# Altium schematic sheet LS1.SchDoc(LS1)
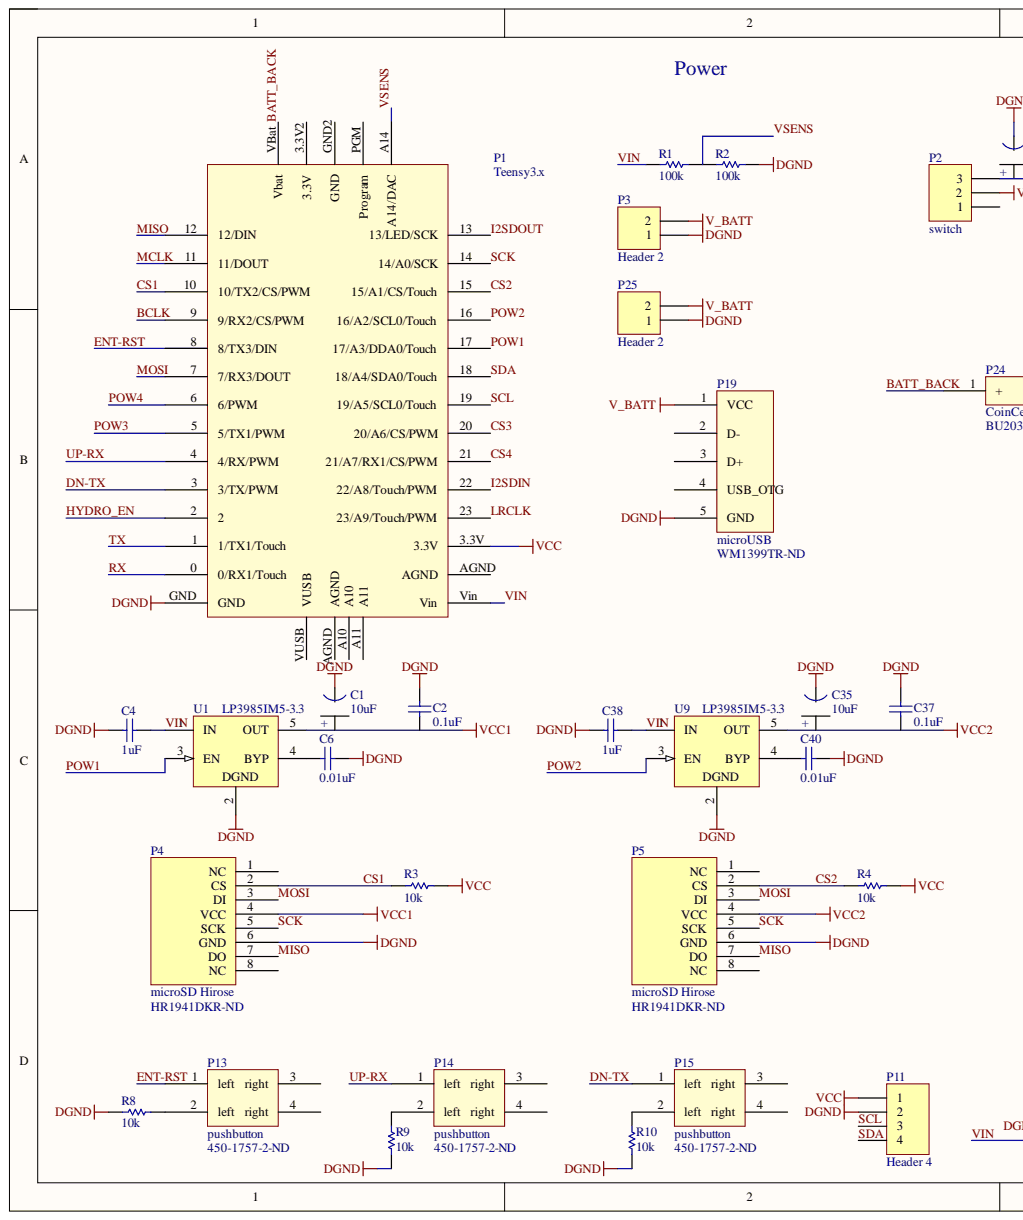
[diagram p0: LS1.SchDoc, left]
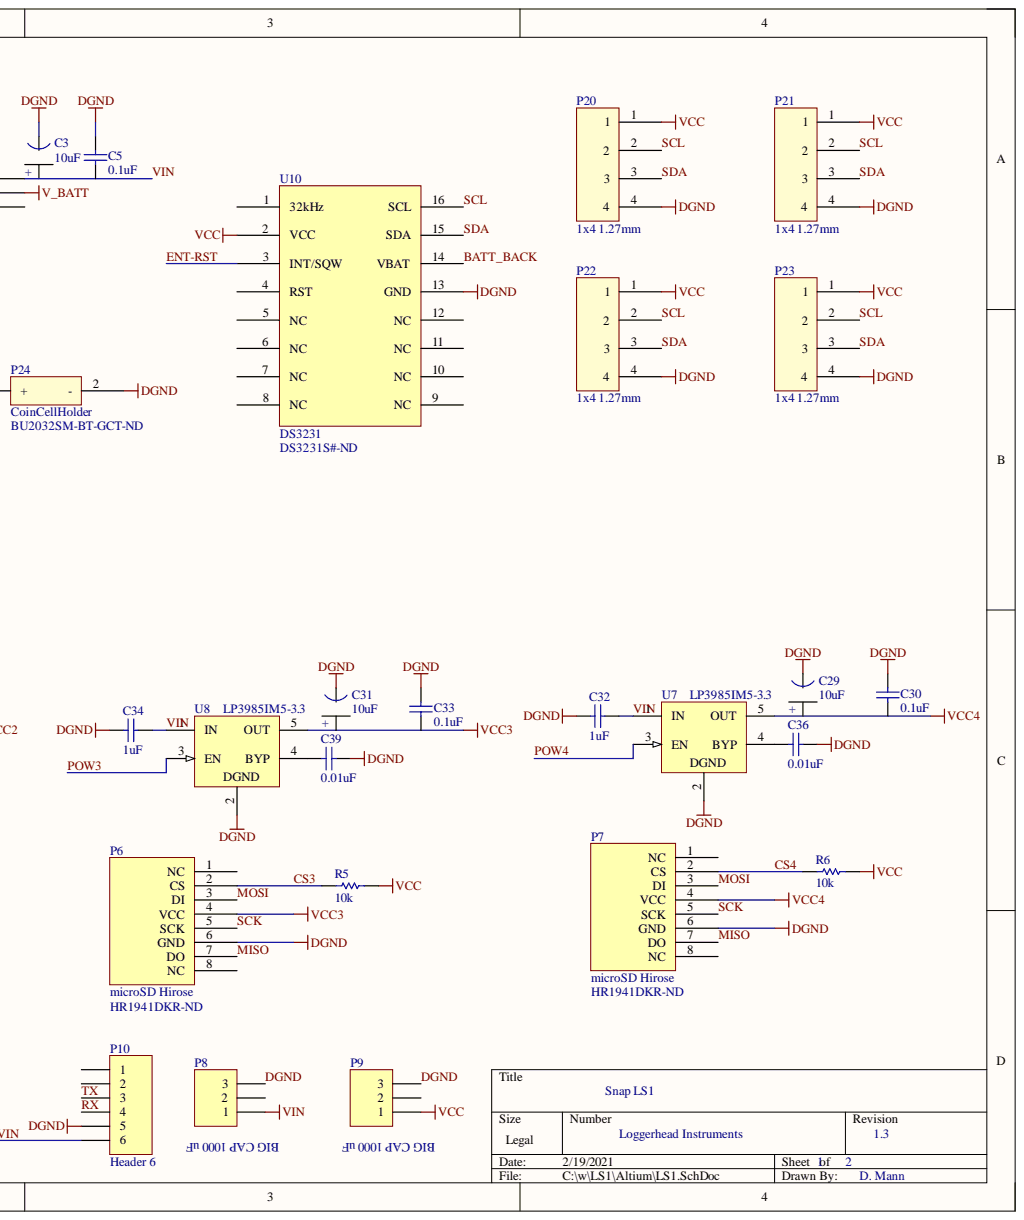
[diagram p1: LS1.SchDoc, right]

## Parts
C1  10uF  [Tant0805]
C2  0.1uF  [0402]
C3  10uF  [Tant0805]
C4  1uF  [0402]
C5  0.1uF  [0402]
C6  0.01uF  [0402]
C29  10uF  [Tant0805]
C30  0.1uF  [0402]
C31  10uF  [Tant0805]
C32  1uF  [0402]
C33  0.1uF  [0402]
C34  1uF  [0402]
C35  10uF  [Tant0805]
C36  0.01uF  [0402]
C37  0.1uF  [0402]
C38  1uF  [0402]
C39  0.01uF  [0402]
C40  0.01uF  [0402]
P1  Teensy3.x  [Teensy3.x]
P2  switch  [HDR1X3]
P3  Header 2  [HDR1X2]
P4  microSD Hirose  Supplier Part Number 1: HR1941DKR-ND  [DM3D microSD]
P5  microSD Hirose  Supplier Part Number 1: HR1941DKR-ND  [DM3D microSD]
P6  microSD Hirose  Supplier Part Number 1: HR1941DKR-ND  [DM3D microSD]
P7  microSD Hirose  Supplier Part Number 1: HR1941DKR-ND  [DM3D microSD]
P8  BIG CAP 1000 uF  [HDR1X3]
P9  BIG CAP 1000 uF  [HDR1X3]
P10  Header 6  [HDR1X6]
P11  Header 4  [HDR1X4]
P13  pushbutton  Supplier Part Number 1: 450-1757-2-ND  [SOP4]
P14  pushbutton  Supplier Part Number 1: 450-1757-2-ND  [SOP4]
P15  pushbutton  Supplier Part Number 1: 450-1757-2-ND  [SOP4]
P19  microUSB  Supplier Part Number 1: WM1399TR-ND  [microUSB-B]
P20  1x4 1.27mm  [Header1x4 1.27mm]
P21  1x4 1.27mm  [Header1x4 1.27mm]
P22  1x4 1.27mm  [Header1x4 1.27mm]
P23  1x4 1.27mm  [Header1x4 1.27mm]
P24  CoinCellHolder  Supplier Part Number 1: BU2032SM-BT-GCT-ND  [CoinCellHolder]
P25  Header 2  [HDR1X2]
R1  100k  [3-0603]
R2  100k  [3-0603]
R3  10k  [3-0603]
R4  10k  [3-0603]
R5  10k  [3-0603]
R6  10k  [3-0603]
R8  10k  [3-0603]
R9  10k  [3-0603]
R10  10k  [3-0603]
U1  LP3985IM5-3.3  [MF05A_N]
U7  LP3985IM5-3.3  [MF05A_N]
U8  LP3985IM5-3.3  [MF05A_N]
U9  LP3985IM5-3.3  [MF05A_N]
U10  DS3231  Supplier Part Number 1: DS3231S#-ND  [DS3231]

## Nets
V_BATT: P2.2 P3.2 P19.1(VCC) P25.2
VCC: P1.3.3V P9.1 P11.1 P20.1 P21.1 P22.1 P23.1 R3.1 R4.1 R5.1 R6.1 U10.2(VCC)
VCC1: C1.2 C2.1 P4.4(VCC) U1.5(OUT)
VCC2: C35.2 C37.1 P5.4(VCC) U9.5(OUT)
VCC3: C31.2 C33.1 P6.4(VCC) U8.5(OUT)
VCC4: C29.2 C30.1 P7.4(VCC) U7.5(OUT)
BATT_BACK: P1.VBat(Vbat) P24.1 U10.14(VBAT)
BCLK: P1.9(9/RX2/CS/PWM)
CS1: P1.10(10/TX2/CS/PWM) P4.2(CS) R3.2
CS2: P1.15(15/A1/CS/Touch) P5.2(CS) R4.2
CS3: P1.20(20/A6/CS/PWM) P6.2(CS) R5.2
CS4: P1.21(21/A7/RX1/CS/PWM) P7.2(CS) R6.2
DGND: C1.1 C2.2 C3.1 C4.2 C5.2 C6.2 C29.1 C30.2 C31.1 C32.2 C33.2 C34.2 C35.1 C36.2 C37.2 C38.2 C39.2 C40.2 P1.GND P3.1 P4.6(GND) P5.6(GND) P6.6(GND) P7.6(GND) P8.3 P9.3 P10.5 P11.2 P19.5(GND) P20.4 P21.4 P22.4 P23.4 P24.2 P25.1 R2.1 R8.2 R9.2 R10.2 U1.2 U7.2 U8.2 U9.2 U10.13(GND)
DN-TX: P1.3(3/TX/PWM) P15.1(left)
ENT-RST: P1.8(8/TX3/DIN) P13.1(left) U10.3(INT/SQW)
HYDRO_EN: P1.2
I2SDIN: P1.22(22/A8/Touch/PWM)
I2SDOUT: P1.13(13/LED/SCK)
LRCLK: P1.23(23/A9/Touch/PWM)
MCLK: P1.11(11/DOUT)
MISO: P1.12(12/DIN) P4.7(DO) P5.7(DO) P6.7(DO) P7.7(DO)
MOSI: P1.7(7/RX3/DOUT) P4.3(DI) P5.3(DI) P6.3(DI) P7.3(DI)
POW1: P1.17(17/A3/DDA0/Touch) U1.3(EN)
POW2: P1.16(16/A2/SCL0/Touch) U9.3(EN)
POW3: P1.5(5/TX1/PWM) U8.3(EN)
POW4: P1.6(6/PWM) U7.3(EN)
RX: P1.0(0/RX1/Touch) P10.4
SCK: P1.14(14/A0/SCK) P4.5(SCK) P5.5(SCK) P6.5(SCK) P7.5(SCK)
SCL: P1.19(19/A5/SCL0/Touch) P11.3 P20.2 P21.2 P22.2 P23.2 U10.16(SCL)
SDA: P1.18(18/A4/SDA0/Touch) P11.4 P20.3 P21.3 P22.3 P23.3 U10.15(SDA)
TX: P1.1(1/TX1/Touch) P10.3
UP-RX: P1.4(4/RX/PWM) P14.1(left)
VIN: C3.2 C4.1 C5.1 C32.1 C34.1 C38.1 P1.Vin P2.3 P8.1 P10.6 R1.2 U1.1(IN) U7.1(IN) U8.1(IN) U9.1(IN)
VSENS: P1.A14(A14/DAC) R1.1 R2.2
NetC6_1: C6.1 U1.4(BYP)
NetC36_1: C36.1 U7.4(BYP)
NetC39_1: C39.1 U8.4(BYP)
NetC40_1: C40.1 U9.4(BYP)
NetP13_2: P13.2(left) R8.1
NetP14_2: P14.2(left) R9.1
NetP15_2: P15.2(left) R10.1

Not connected: P1 3.3V2(3.3V) A10 A11 AGND GND2(GND) PGM(Program) VUSB; P2 1; P4 1(NC) 8(NC); P5 1(NC) 8(NC); P6 1(NC) 8(NC); P7 1(NC) 8(NC); P8 2; P9 2; P10 1 2; P13 3(right) 4(right); P14 3(right) 4(right); P15 3(right) 4(right); P19 2(D-) 3(D+) 4; U10 1(32kHz) 4(RST) 5(NC) 6(NC) 7(NC) 8(NC) 9(NC) 10(NC) 11(NC) 12(NC)

## Library data of the parts
C1 C3 C29 C31 C35 = Tant0805: Component Kind: Standard; Value: 10uF; Library Name: Loggerhead.IntLib
C2 C5 C30 C33 C37 = C0402: Ceramic Chip Capacitor - Standard; Note: Footprint corresponds to dimensions for bulk cassette packaging. This case size has tightened tolerances.; Code_IPC: 1005 [0402]; Code_JEITA: 0402; Component Kind: Standard; ComponentLink1Description: Manufacturer Link; ComponentLink1URL: http://www.kemet.com/; ComponentLink2Description: Datasheet; ComponentLink2URL: http://www.kemet.com/kemet/web/homepage/kechome.nsf/vapubfilesname/F3102TA.pdf/$file/F3102TA.pdf; DatasheetDocument: Mar-2001; LatestRevisionDate: 19-Mar-2004; LatestRevisionNote: Silkscreen size reduced.; Library Name: KEMET Chip Capacitor.IntLib; PackageDocument: Mar-2001; PackageReference: 0402; Published: 17-Jul-2002; Signal Integrity: C0402; Simulation: CAP; Value: 0.1uF; Publisher: Altium Limited
C4 C6 C32 C34 C36 C38-C40 = C0402: Ceramic Chip Capacitor - Standard; Note: Footprint corresponds to dimensions for bulk cassette packaging. This case size has tightened tolerances.; Value: 0.1uF; Code_IPC: 1005 [0402]; Code_JEITA: 0402; Component Kind: Standard; ComponentLink1Description: Manufacturer Link; ComponentLink1URL: http://www.kemet.com/; ComponentLink2Description: Datasheet; ComponentLink2URL: http://www.kemet.com/kemet/web/homepage/kechome.nsf/vapubfilesname/F3102TA.pdf/$file/F3102TA.pdf; DatasheetDocument: Mar-2001; LatestRevisionDate: 19-Mar-2004; LatestRevisionNote: Silkscreen size reduced.; Library Name: KEMET Chip Capacitor.IntLib; PackageDocument: Mar-2001; PackageReference: 0402; Published: 17-Jul-2002; Signal Integrity: C0402; Simulation: CAP; Publisher: Altium Limited
P2 P8 P9 = Header 3: Header, 3-Pin; Component Kind: Standard; LatestRevisionDate: 17-Jul-2002; LatestRevisionNote: Re-released for DXP Platform.; Library Name: Miscellaneous Connectors.IntLib; Signal Integrity: Connector; Publisher: Altium Limited
P3 P25 = Header 2: Header, 2-Pin; Component Kind: Standard; LatestRevisionDate: 17-Jul-2002; LatestRevisionNote: Re-released for DXP Platform.; Library Name: Miscellaneous Connectors.IntLib; Signal Integrity: Connector; Publisher: Altium Limited
P10 = Header 6: Header, 6-Pin; Component Kind: Standard; LatestRevisionDate: 17-Jul-2002; LatestRevisionNote: Re-released for DXP Platform.; Library Name: Miscellaneous Connectors.IntLib; Signal Integrity: Connector; Publisher: Altium Limited
P11 = Header 4: Header, 4-Pin; Component Kind: Standard; LatestRevisionDate: 17-Jul-2002; LatestRevisionNote: Re-released for DXP Platform.; Library Name: Miscellaneous Connectors.IntLib; Signal Integrity: Connector; Publisher: Altium Limited
P19 = microUSB-B: Component Kind: Standard; Supplier 1: Digi-Key; Library Name: Loggerhead.IntLib
P20-P23 = Header 1x4 1.27mm: Component Kind: Standard; Library Name: Loggerhead.IntLib
R1-R6 R8-R10 = ERJ3RK: Precision Thick Film Chip Resistor, 10 Ohm to 1M Ohm Range, 1% and 0.5% Tolerance, 0603 Size, 0.063 W; Code_IPC: 1608 [0603]; Component Kind: Standard; ComponentLink1Description: Manufacturer Link; ComponentLink1URL: http://www.panasonic.com/industrial/components/resistive_products.htm; ComponentLink2Description: Datasheet; ComponentLink2URL: http://www.panasonic.com/industrial/components/pdf/AOA0000CE2.pdf; LatestRevisionDate: 19-Mar-2004; LatestRevisionNote: Silkscreen size reduced.; Library Name: Panasonic Resistor.IntLib; PackageDocument: Mar-2003; PackageReference: 3-0603; Published: 17-Dec-2003; Signal Integrity: Res; Simulation: RESISTOR; Publisher: Altium Limited
U1 U7-U9 = LP3985IM5-3.3: Micropower, 150mA Low-Noise Ultra Low-Dropout CMOS Voltage Regulator; Manufacturer: National Semiconductor; CompType: LDO IC; PackageTopMarking: LDQB*XTT; PowerWise: N; Component Kind: Standard; ComponentLink1Description: LP3985 Datasheet; ComponentLink1URL: http://www.national.com/ds/LP/LP3985.pdf; ComponentLink2Description: LP3985 Web Page; ComponentLink2URL: http://www.national.com/pf/LP/LP3985.html; ComponentLink3Description: MF05A Package Page; ComponentLink3URL: http://www.national.com/packaging/folders/mf05a.html; DatasheetVersion: Aug-2005; DigiKeyPartNumber: LP3985IM5-3.3CT-ND; Library Name: NSC LDO.IntLib; PackageDescription: Molded SOT-23, .115 x .063 x .040 in Body, 5 Lead, .0375 in Pitch; PackageReference: MF05A; PackageVersion: revD, Aug-2008; PartNumber: LP3985IM5-3.3; PinCount: 5; Published: 4-Dec-2008; RoHS: O; Publisher: Altium Limited
P1 = Teensy3.x: Component Kind: Standard; Library Name: Teensy.IntLib
P4-P7 = microSD Hirose: Component Kind: Standard; Supplier 1: Digi-Key; Library Name: Loggerhead.IntLib
P13-P15 = pushbutton: Component Kind: Standard; Supplier 1: Digi-Key; Library Name: Loggerhead.IntLib
P24 = CoinCellHolder: Component Kind: Standard; Supplier 1: Digi-Key; Library Name: Loggerhead.IntLib
U10 = DS3231: Component Kind: Standard; Supplier 1: Digi-Key; Library Name: Loggerhead.IntLib
